# Altium schematic sheet MIAN PORT.SchDoc("MIAN PORT")
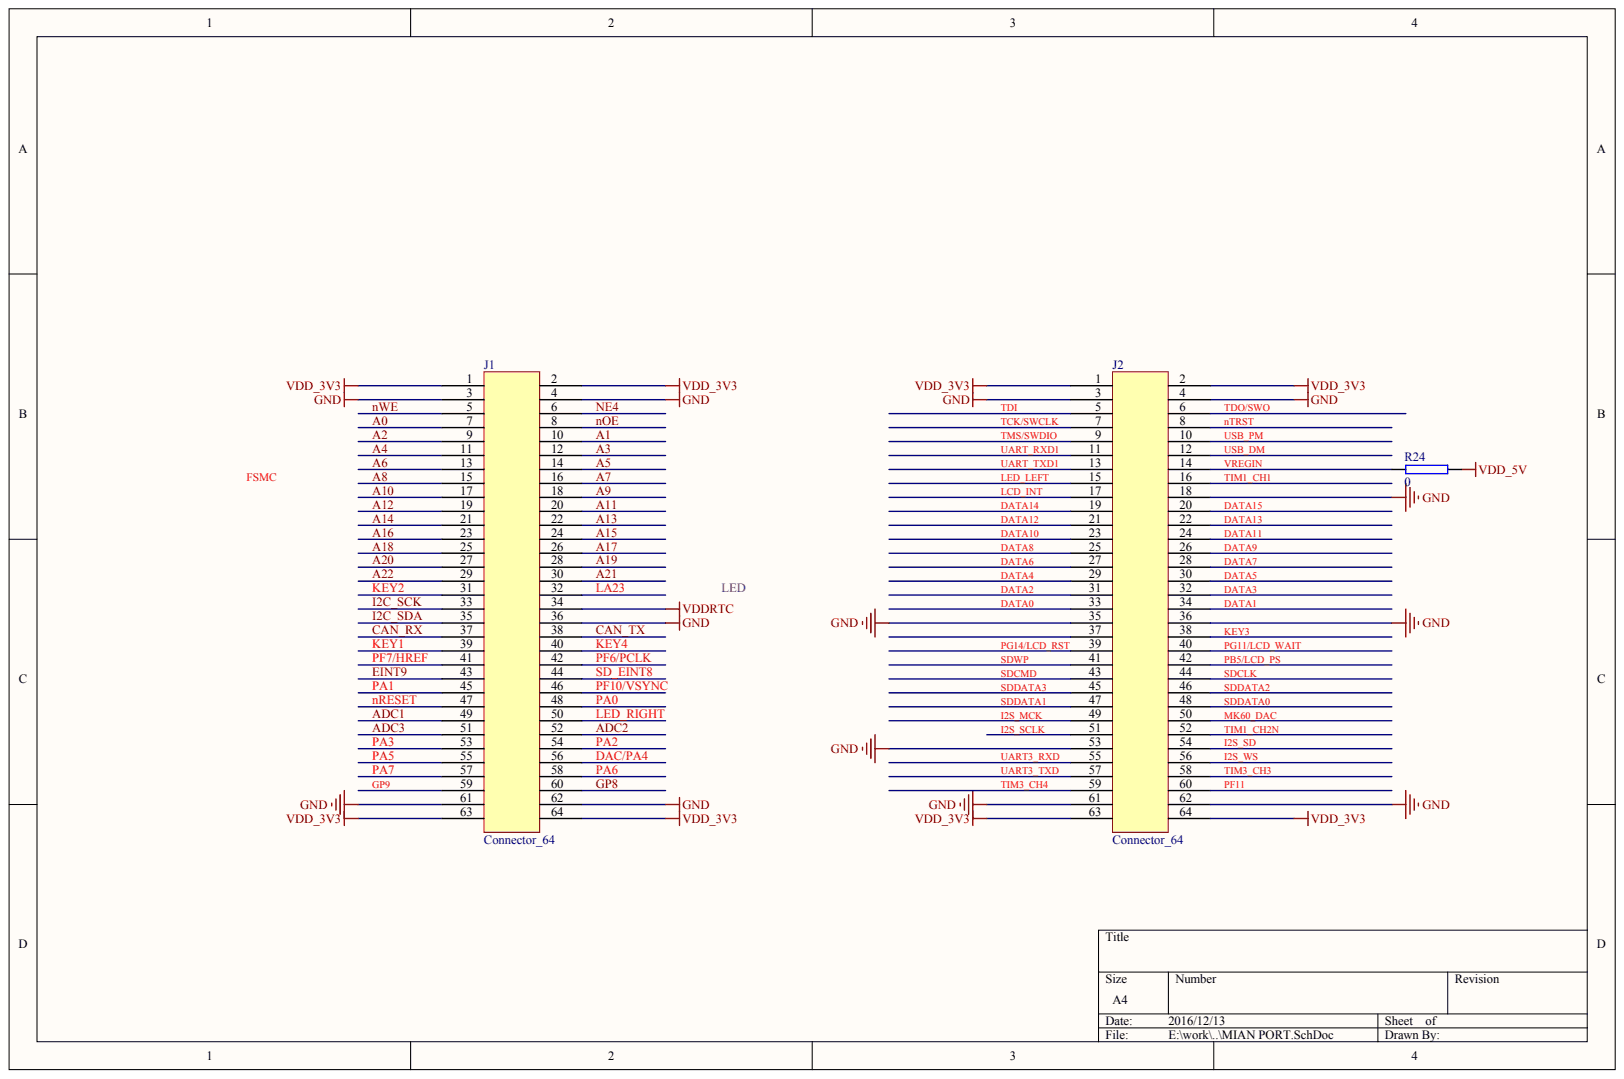
[diagram p0: MIAN PORT.SchDoc]

## Parts
J1  Connector_64
J2  Connector_64
R24  0

## Nets
GND: J1.3 J1.4 J1.36 J1.61 J1.62 J2.3 J2.4 J2.18 J2.35 J2.36 J2.53 J2.61 J2.62
VDD_3V3: J1.1 J1.2 J1.63 J1.64 J2.1 J2.2 J2.63 J2.64
VDD_5V: R24.1
VDDRTC: J1.34
A0: J1.7
A1: J1.10
A2: J1.9
A3: J1.12
A4: J1.11
A5: J1.14
A6: J1.13
A7: J1.16
A8: J1.15
A9: J1.18
A10: J1.17
A11: J1.20
A12: J1.19
A13: J1.22
A14: J1.21
A15: J1.24
A16: J1.23
A17: J1.26
A18: J1.25
A19: J1.28
A20: J1.27
A21: J1.30
A22: J1.29
ADC1: J1.49
ADC2: J1.52
ADC3: J1.51
CAN_RX: J1.37
CAN_TX: J1.38
DAC/PA4: J1.56
DATA0: J2.33
DATA1: J2.34
DATA2: J2.31
DATA3: J2.32
DATA4: J2.29
DATA5: J2.30
DATA6: J2.27
DATA7: J2.28
DATA8: J2.25
DATA9: J2.26
DATA10: J2.23
DATA11: J2.24
DATA12: J2.21
DATA13: J2.22
DATA14: J2.19
DATA15: J2.20
EINT9: J1.43
GP8: J1.60
GP9: J1.59
I2C_SCK: J1.33
I2C_SDA: J1.35
I2S_MCK: J2.49
I2S_SCLK: J2.51
I2S_SD: J2.54
I2S_WS: J2.56
KEY1: J1.39
KEY2: J1.31
KEY3: J2.38
KEY4: J1.40
LA23: J1.32
LCD_INT: J2.17
LED_LEFT: J2.15
LED_RIGHT: J1.50
MK60_DAC: J2.50
NE4: J1.6
NOE: J1.8
NRESET: J1.47
NTRST: J2.8
NWE: J1.5
PA0: J1.48
PA1: J1.45
PA2: J1.54
PA3: J1.53
PA5: J1.55
PA6: J1.58
PA7: J1.57
PB5/LCD_PS: J2.42
PF6/PCLK: J1.42
PF7/HREF: J1.41
PF10/VSYNC: J1.46
PF11: J2.60
PG11/LCD_WAIT: J2.40
PG14/LCD_RST: J2.39
SD_EINT8: J1.44
SDCLK: J2.44
SDCMD: J2.43
SDDATA0: J2.48
SDDATA1: J2.47
SDDATA2: J2.46
SDDATA3: J2.45
SDWP: J2.41
TCK/SWCLK: J2.7
TDI: J2.5
TDO/SWO: J2.6
TIM1_CH1: J2.16
TIM1_CH2N: J2.52
TIM3_CH3: J2.58
TIM3_CH4: J2.59
TMS/SWDIO: J2.9
UART3_RXD: J2.55
UART3_TXD: J2.57
UART_RXD1: J2.11
UART_TXD1: J2.13
USB_DM: J2.12
USB_PM: J2.10
VREGIN: J2.14 R24.2

Not connected: J2 37
